# Altium schematic sheet MMB-2013-007a Micro Radio.SchDoc("MMB-2013-007a Micro Radio")
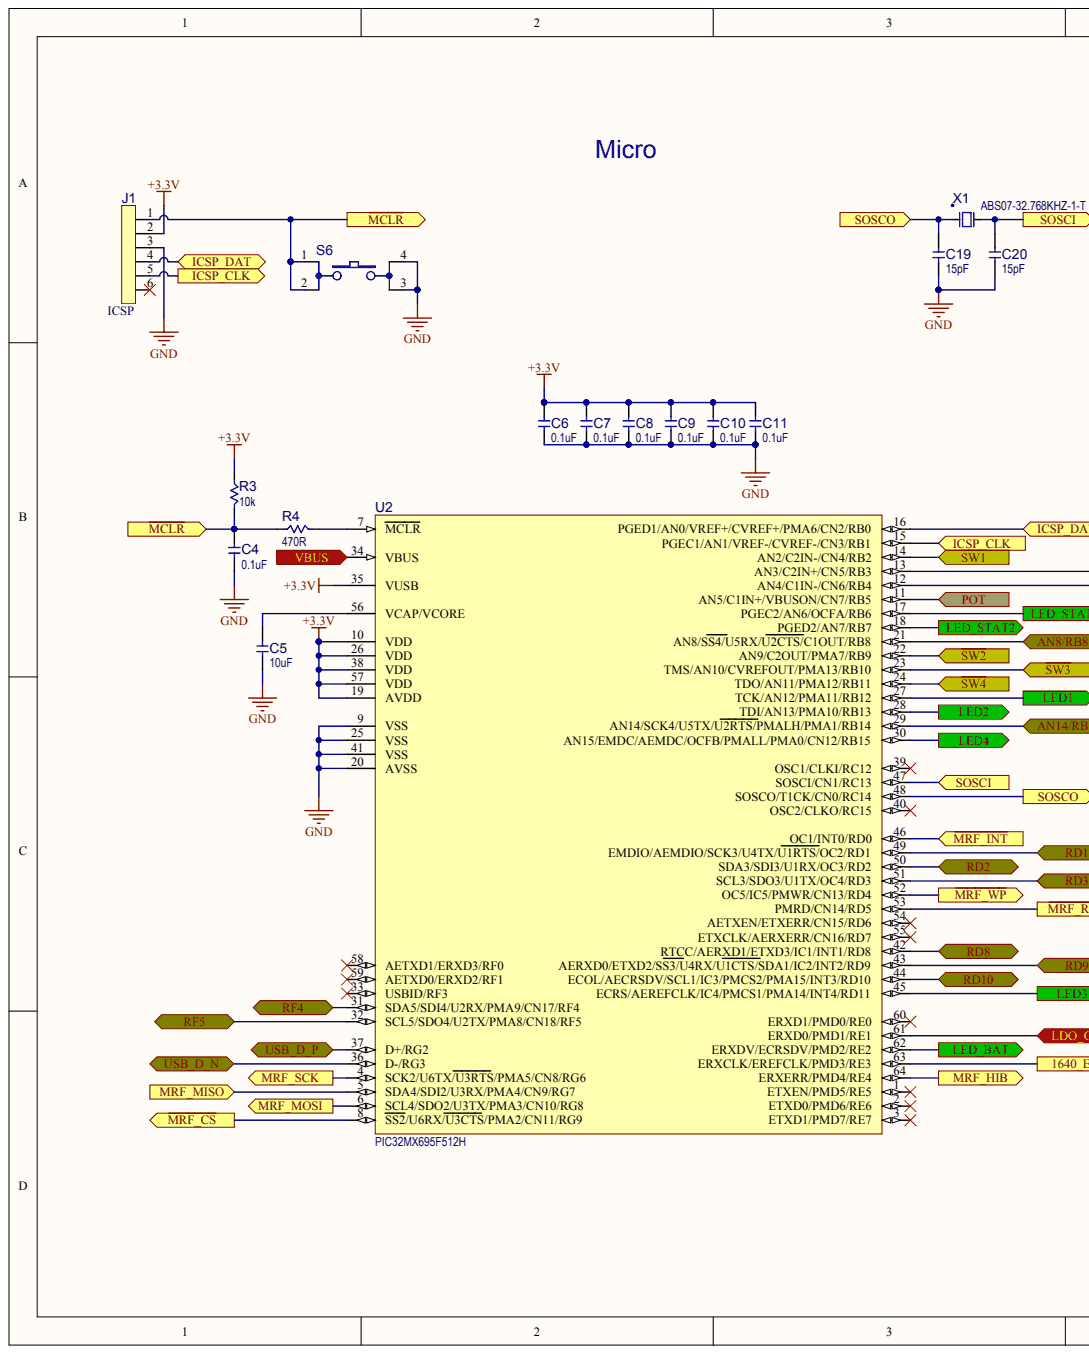
[diagram p0: MMB-2013-007a Micro Radio.SchDoc, left]
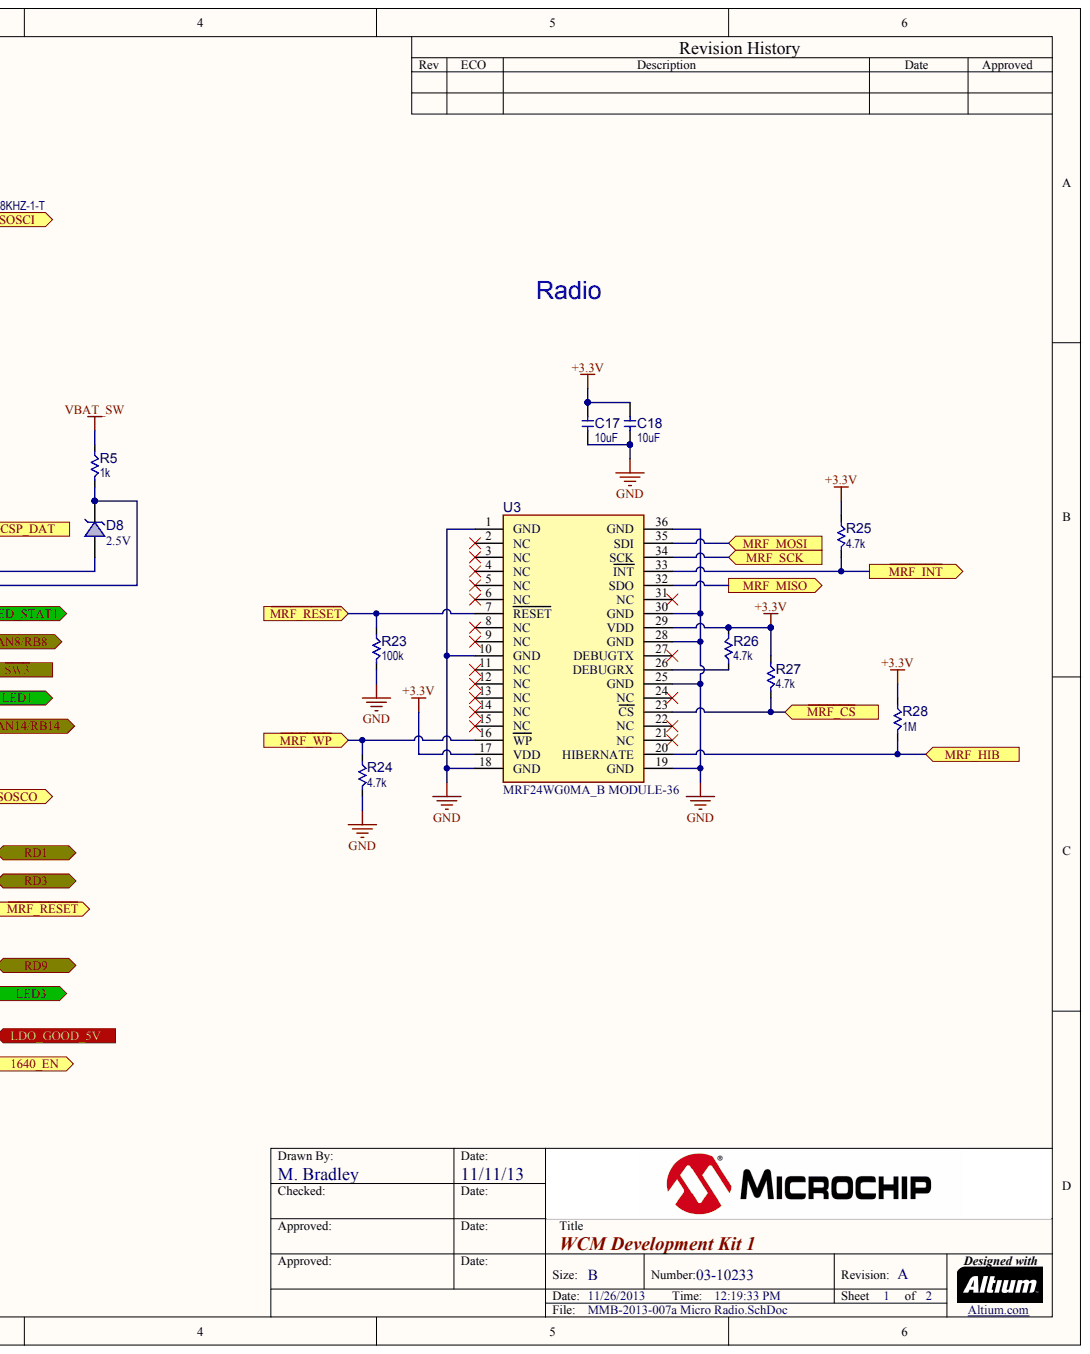
[diagram p1: MMB-2013-007a Micro Radio.SchDoc, right]

## Parts
C4  value 0.1uF  (hidden comment: Capacitor NP)
C5  value 10uF  (hidden comment: Capacitor NP)
C6  value 0.1uF  (hidden comment: Capacitor NP)
C7  value 0.1uF  (hidden comment: Capacitor NP)
C8  value 0.1uF  (hidden comment: Capacitor NP)
C9  value 0.1uF  (hidden comment: Capacitor NP)
C10  value 0.1uF  (hidden comment: Capacitor NP)
C11  value 0.1uF  (hidden comment: Capacitor NP)
C17  value 10uF  (hidden comment: Capacitor NP)
C18  value 10uF  (hidden comment: Capacitor NP)
C19  value 15pF  (hidden comment: Capacitor NP)
C20  value 15pF  (hidden comment: Capacitor NP)
D8  value 2.5V  (hidden comment: Diode Zener)
J1  ICSP
R3  value 10k  (hidden comment: Resistor)
R4  value 470R  (hidden comment: Resistor)
R5  value 1k  (hidden comment: Resistor)
R23  value 100k  (hidden comment: Resistor)
R24  value 4.7k  (hidden comment: Resistor)
R25  value 4.7k  (hidden comment: Resistor)
R26  value 4.7k  (hidden comment: Resistor)
R27  value 4.7k  (hidden comment: Resistor)
R28  value 1M  (hidden comment: Resistor)
S6  (no value)
U2  value PIC32MX695F512H  (hidden comment: PIC32MX6XX TQFP-64)
U3  MRF24WG0MA_B MODULE-36
X1  Manufacturer Part Number 1: ABS07-32.768KHZ-1-T  (hidden comment: Crystal 2 Pins)

## Ports
1640_EN, AN8/RB8, AN14/RB14, ICSP_CLK, ICSP_DAT, LDO_GOOD_5V, LED1, LED2, LED3, LED4, LED_BAT, LED_STAT1, LED_STAT2, MRF_HIB, MRF_MISO, MRF_MOSI, MRF_SCK, POT, RD1, RD2, RD3, RD8, RD9, RD10, RF4, RF5, SOSCI, SOSCO, USB_D_N, USB_D_P, VBUS, ~{MCLR}, ~{MRF_CS}, ~{MRF_INT}, ~{MRF_RESET}, ~{MRF_WP}, ~{SW1}, ~{SW2}, ~{SW3}, ~{SW4}

## Nets
+3.3V: C6.2 C7.2 C8.2 C9.2 C10.2 C11.2 C17.2 C18.2 J1.2 R3.2 R25.2 R26.1 R27.2 R28.2 U2.10(VDD) U2.19(AVDD) U2.26(VDD) U2.35(VUSB) U2.38(VDD) U2.57(VDD) U3.17(VDD) U3.29(VDD)
GND: C4.1 C5.1 C6.1 C7.1 C8.1 C9.1 C10.1 C11.1 C17.1 C18.1 C19.1 C20.1 J1.3 R23.1 R24.1 S6.3 S6.4 U2.9(VSS) U2.20(AVSS) U2.25(VSS) U2.41(VSS) U3.1(GND) U3.10(GND) U3.18(GND) U3.19(GND) U3.25(GND) U3.28(GND) U3.30(GND) U3.36(GND)
VBAT_SW: R5.2
1640_EN: U2.63(ERXCLK/EREFCLK/PMD3/RE3) ; port 1640_EN
AN8/RB8: U2.21(AN8/SS4/U5RX/U2CTS/C1OUT/RB8) ; port AN8/RB8
AN14/RB14: U2.29(AN14/SCK4/U5TX/U2RTS/PMALH/PMA1/RB14) ; port AN14/RB14
ICSP_CLK: J1.5 U2.15(PGEC1/AN1/VREF-/CVREF-/CN3/RB1) ; port ICSP_CLK
ICSP_DAT: J1.4 U2.16(PGED1/AN0/VREF+/CVREF+/PMA6/CN2/RB0) ; port ICSP_DAT
LDO_GOOD_5V: U2.61(ERXD0/PMD1/RE1) ; port LDO_GOOD_5V
LED1: U2.27(TCK/AN12/PMA11/RB12) ; port LED1
LED2: U2.28(TDI/AN13/PMA10/RB13) ; port LED2
LED3: U2.45(ECRS/AEREFCLK/IC4/PMCS1/PMA14/INT4/RD11) ; port LED3
LED4: U2.30(AN15/EMDC/AEMDC/OCFB/PMALL/PMA0/CN12/RB15) ; port LED4
LED_BAT: U2.62(ERXDV/ECRSDV/PMD2/RE2) ; port LED_BAT
LED_STAT1: U2.17(PGEC2/AN6/OCFA/RB6) ; port LED_STAT1
LED_STAT2: U2.18(PGED2/AN7/RB7) ; port LED_STAT2
~{MCLR}: C4.2 J1.1 R3.1 R4.2 S6.1 S6.2 ; port ~{MCLR}
~{MRF_CS}: R27.1 U2.8(SS2/U6RX/U3CTS/PMA2/CN11/RG9) U3.23(CS) ; port ~{MRF_CS}
~{MRF_INT}: R25.1 U2.46(OC1/INT0/RD0) U3.33(INT) ; port ~{MRF_INT}
~{MRF_RESET}: R23.2 U2.53(PMRD/CN14/RD5) U3.7(RESET) ; port ~{MRF_RESET}
~{MRF_WP}: R24.2 U2.52(OC5/IC5/PMWR/CN13/RD4) U3.16(WP) ; port ~{MRF_WP}
MRF_HIB: R28.1 U2.64(ERXERR/PMD4/RE4) U3.20(HIBERNATE) ; port MRF_HIB
MRF_MISO: U2.5(SDA4/SDI2/U3RX/PMA4/CN9/RG7) U3.32(SDO) ; port MRF_MISO
MRF_MOSI: U2.6(SCL4/SDO2/U3TX/PMA3/CN10/RG8) U3.35(SDI) ; port MRF_MOSI
MRF_SCK: U2.4(SCK2/U6TX/U3RTS/PMA5/CN8/RG6) U3.34(SCK) ; port MRF_SCK
POT: U2.11(AN5/C1IN+/VBUSON/CN7/RB5) ; port POT
RD1: U2.49(EMDIO/AEMDIO/SCK3/U4TX/U1RTS/OC2/RD1) ; port RD1
RD2: U2.50(SDA3/SDI3/U1RX/OC3/RD2) ; port RD2
RD3: U2.51(SCL3/SDO3/U1TX/OC4/RD3) ; port RD3
RD8: U2.42(RTCC/AERXD1/ETXD3/IC1/INT1/RD8) ; port RD8
RD9: U2.43(AERXD0/ETXD2/SS3/U4RX/U1CTS/SDA1/IC2/INT2/RD9) ; port RD9
RD10: U2.44(ECOL/AECRSDV/SCL1/IC3/PMCS2/PMA15/INT3/RD10) ; port RD10
RF4: U2.31(SDA5/SDI4/U2RX/PMA9/CN17/RF4) ; port RF4
RF5: U2.32(SCL5/SDO4/U2TX/PMA8/CN18/RF5) ; port RF5
~{SW1}: U2.14(AN2/C2IN-/CN4/RB2) ; port ~{SW1}
~{SW2}: U2.22(AN9/C2OUT/PMA7/RB9) ; port ~{SW2}
~{SW3}: U2.23(TMS/AN10/CVREFOUT/PMA13/RB10) ; port ~{SW3}
~{SW4}: U2.24(TDO/AN11/PMA12/RB11) ; port ~{SW4}
SOSCI: C20.2 U2.47(SOSCI/CN1/RC13) X1.2 ; port SOSCI
SOSCO: C19.2 U2.48(SOSCO/T1CK/CN0/RC14) X1.1 ; port SOSCO
USB_D_N: U2.36(D-/RG3) ; port USB_D_N
USB_D_P: U2.37(D+/RG2) ; port USB_D_P
VBUS: U2.34(VBUS) ; port VBUS
NetC5_2: C5.2 U2.56(VCAP/VCORE)
NetD8_1: D8.1 U2.13(AN3/C2IN+/CN5/RB3)
NetD8_3: D8.3 R5.1 U2.12(AN4/C1IN-/CN6/RB4)
NetR4_1: R4.1 U2.7(MCLR)
NetR26_2: R26.2 U3.26(DEBUGRX)

Not connected: J1 6; U2 1(ETXEN/PMD5/RE5) 2(ETXD0/PMD6/RE6) 3(ETXD1/PMD7/RE7) 33(USBID/RF3) 39(OSC1/CLKI/RC12) 40(OSC2/CLKO/RC15) 54(AETXEN/ETXERR/CN15/RD6) 55(ETXCLK/AERXERR/CN16/RD7) 58(AETXD1/ERXD3/RF0) 59(AETXD0/ERXD2/RF1) 60(ERXD1/PMD0/RE0); U3 2(NC) 3(NC) 4(NC) 5(NC) 6(NC) 8(NC) 9(NC) 11(NC) 12(NC) 13(NC) 14(NC) 15(NC) 21(NC) 22(NC) 24(NC) 27(DEBUGTX) 31(NC)

## Library data of the parts
C4 C6-C11 = (no symbol name): Manufacturer 1: TDK; Manufacturer Part Number 1: C1608X7R1C104K; MCHP-ID: CAP1166; Voltage: 16V; Description_: CAP CER 0.1uF 16V 10% X7R 0603; Tolerance: 10%; Temperature Coeffcient: X7R; Type: Ceramic; Owner: Bogdan P (M91398); Populated: Yes; Package: 0603; Revision: 0; Status: Production; ComponentLink1URL: https://chn-vm-apps.microchip.com/mchprepo/parts/Datasheets/general_B11.pdf; ComponentLink1Description: Datasheet; Operating Temperature: -55C to +125C; Category: Capacitor; Supplier 1: Digi-Key; Supplier Part Number 1: 445-1317-1-ND; Quantity Override: 1
C5 = (no symbol name): Manufacturer 1: Murata; Manufacturer Part Number 1: GRM21BR61C106KE15L; MCHP-ID: CAP0373; Voltage: 16V; Description_: CAP CER 10uF 16V 10% X5R SMD 0805; Tolerance: 10%; Temperature Coeffcient: X5R; Type: Ceramic; Populated: Yes; Package: 0805; Revision: 1; Status: Production; ComponentLink1URL: https://chn-vm-apps.microchip.com/mchprepo/parts/Datasheets/L0005E.pdf; ComponentLink1Description: Datasheet; Operating Temperature: +25C to +125C; Category: Capacitor; Manufacturer 2: Taiyo Yuden; Manufacturer Part Number 2: EMK212BJ106KG-T; Supplier 1: Digi-Key; Supplier Part Number 1: 490-3886-1-ND; Supplier 2: Digi-Key; Supplier Part Number 2: 587-1295-1-ND; Supplier 3: Newark; Supplier Part Number 3: 30K5459; Quantity Override: 1
C17 C18 = (no symbol name): Manufacturer 1: Taiyo Yuden; Manufacturer Part Number 1: JMK212BJ106MG-T; MCHP-ID: CAP0280; Voltage: 6.3V; Description_: CAP CER 10uF 6.3V 20% X5R SMD 0805; Tolerance: 20%; Temperature Coeffcient: X5R; Type: Ceramic; Populated: Yes; Package: 0805; Revision: 1; Status: Production; ComponentLink1URL: https://chn-vm-apps.microchip.com/mchprepo/parts/Datasheets/mlcc01_e.pdf; ComponentLink1Description: Datasheet; Operating Temperature: -55C to +85C; Category: Capacitor; Manufacturer 2: Murata; Manufacturer Part Number 2: GRM21BR60J106ME19L; Supplier 1: Digi-Key; Supplier Part Number 1: 587-1943-1-ND; Supplier 2: Digi-Key; Supplier Part Number 2: 490-1718-1-ND; Quantity Override: 1
C19 C20 = (no symbol name): Manufacturer 1: Panasonic; Manufacturer Part Number 1: ECJ-1VC1H150J; MCHP-ID: CAP0121; Voltage: 50V; Description_: CAP CER 15pF 50V 5% NP0 SMD 0603; Tolerance: 5%; Temperature Coeffcient: NP0; Type: Ceramic; Populated: Yes; Package: 0603; Revision: 1; Status: Production; ComponentLink1URL: https://chn-vm-apps.microchip.com/mchprepo/parts/Datasheets/MLCC_ordering_code.pdf; ComponentLink1Description: Datasheet; Operating Temperature: -55C to +125C; Category: Capacitor; Manufacturer 2: TDK; Manufacturer Part Number 2: C1608C0G1H150J; Manufacturer 3: Yageo; Manufacturer Part Number 3: CC0603JRNP09BN150; Supplier 1: Digi-Key; Supplier Part Number 1: PCC150ACVTR-ND; Supplier 2: Digi-Key; Supplier Part Number 2: 445-1271-1-ND; Supplier 3: Digi-Key; Supplier Part Number 3: 311-1060-1-ND; Quantity Override: 1
D8 = (no symbol name): Manufacturer 1: ON Semiconductor; Manufacturer Part Number 1: MMBZ5222BLT1G; MCHP-ID: DIODE1083; Description_: DIO ZNR MMBZ5222BLT1G 2.5V 225mW SMD SOT-23-3; Type: Zener Single; Forward Current: 10mA; Power: 225mW; Reverse Current: 100uA; Reverse Voltage: 1V; Populated: YES; Revision: B; Status: Design; Package: SOT-23-3; ComponentLink1URL: https://chn-vm-apps.microchip.com/mchprepo/parts/Datasheets/MMBZ5221BLT1-D.pdf; ComponentLink1Description: Datasheet; Operating Temperature: -65C to 150C; Category: Diode; Supplier 1: Digi-Key; Supplier Part Number 1: MMBZ5222BLT1GOSCT-ND; Quantity Override: 1
J1 = (no symbol name): Manufacturer 1: FCI; Manufacturer Part Number 1: 68016-106HLF; MCHP-ID: CON1017; Value: HDR-2.54 Male 1x6; Description_: CON HDR-2.54 Male 1x6 Gold 5.84MH TH R/A; Owner: Leah Whitaker; Populated: YES; Revision: 1; Status: Production; ComponentLink1URL: https://chn-vm-apps.microchip.com/mchprepo/parts/Datasheets/68015.pdf; ComponentLink1Description: Datasheet; Type: Header 2.54mm; Category: Connector; Supplier 1: Digi-Key; Supplier Part Number 1: 609-3313-ND; Quantity Override: 1
R3 = (no symbol name): Manufacturer 1: Panasonic; Manufacturer Part Number 1: ERJ-3GEYJ103V; MCHP-ID: RES0191; Tolerance: 5%; Description_: RES TKF 10k 5% 1/10W SMD 0603; Voltage: 75V; Temperature Coeffcient: 200ppm/C; Power: 1/10W; Populated: Yes; Package: 0603; Revision: 2; Status: Production; ComponentLink1URL: https://chn-vm-apps.microchip.com/mchprepo/parts/Datasheets/AOA0000CE1.pdf; ComponentLink1Description: Datasheet; Operating Temperature: -55C to +155C; Category: Resistor; Type: RES SMD; Composition: TKF; Manufacturer 2: NIC Components; Manufacturer Part Number 2: NRC06J103TRF; Manufacturer 3: Yageo; Manufacturer Part Number 3: RC0603JR-0710KL; Supplier 1: Digi-Key; Supplier Part Number 1: P10KGCT-ND; Supplier 2: Digi-Key; Supplier Part Number 2: P10KGTR-ND; Supplier 3: NIC Components; Supplier Part Number 3: NRC06J103TRF; Supplier 4: Digi-Key; Supplier Part Number 4: 311-10KGRTR-ND; Quantity Override: 1
R4 = (no symbol name): Manufacturer 1: NIC Components; Manufacturer Part Number 1: NRC06J471TRF; MCHP-ID: RSMT0098; Tolerance: 5%; Description_: RES TKF 470R 5% 1/10W SMD 0603; Temperature Coeffcient: 200ppm/C; Power: 1/10W; Populated: Yes; Package: 0603; Revision: 1; Status: Production; ComponentLink1URL: https://chn-vm-apps.microchip.com/mchprepo/parts/Datasheets/nrc.pdf; ComponentLink1Description: Datasheet; Operating Temperature: -55C to +155C; Category: Resistor; Type: RES SMD; Composition: TKF; Manufacturer 2: Panasonic; Manufacturer Part Number 2: ERJ-3GEYJ471V; Manufacturer 3: Stackpole Electronics Inc; Manufacturer Part Number 3: RMCF0603JT470R; Supplier 1: NIC Components; Supplier Part Number 1: NRC06J471TRF; Supplier 2: Digi-Key; Supplier Part Number 2: P470GTR-ND; Supplier 3: Digi-Key; Supplier Part Number 3: RMCF0603JT470RCT-ND; Quantity Override: 1
R5 = (no symbol name): Manufacturer 1: Panasonic; Manufacturer Part Number 1: ERJ-3GEYJ102V; MCHP-ID: RSMT0047; Tolerance: 5%; Description_: RES TKF 1k 5% 1/10W SMD 0603; Voltage: 75V; Temperature Coeffcient: 200ppm/C; Power: 1/10W; Populated: Yes; Package: 0603; Revision: 1; Status: Production; ComponentLink1URL: https://chn-vm-apps.microchip.com/mchprepo/parts/Datasheets/AOA0000CE1.pdf; ComponentLink1Description: Datasheet; Operating Temperature: -55C to +155C; Category: Resistor; Type: RES SMD; Composition: TKF; Manufacturer 2: KOA Speer; Manufacturer Part Number 2: RK73B1JTTD102J; Manufacturer 3: Panasonic; Manufacturer Part Number 3: ERJ-3GSYJ102V; Manufacturer 4: ROHM; Manufacturer Part Number 4: MCR03EZHJ1K; Manufacturer 5: ROHM; Manufacturer Part Number 5: MCR03FZHJ1001; Manufacturer 6: Stackpole Electronics Inc; Manufacturer Part Number 6: RMCF 1/16 1K 5% R; Manufacturer 7: Yageo; Manufacturer Part Number 7: RC0603JR-071KL; Supplier 1: Digi-Key; Supplier Part Number 1: P1.0KGCT-ND; Supplier 2: Digi-Key; Supplier Part Number 2: P1.0KGTR-ND; Supplier 3: KOA Speer; Supplier Part Number 3: RK73B1JTTD102J; Supplier 4: ROHM; Supplier Part Number 4: MCR03EZHJ1K; Supplier 5: ROHM; Supplier Part Number 5: MCR03FZHJ1001; Supplier 6: Stackpole Electronics Inc; Supplier Part Number 6: RMCF 1/16 1K 5% R; Supplier 7: Digi-Key; Supplier Part Number 7: 311-1.0KGRTR-ND; Quantity Override: 1
R23 = (no symbol name): Manufacturer 1: Panasonic; Manufacturer Part Number 1: ERJ-3GEYJ104V; MCHP-ID: RSMT0026; Tolerance: 5%; Description_: RES TKF 100k 5% 1/10W SMD 0603; Voltage: 75V; Temperature Coeffcient: 200ppm/C; Power: 1/10W; Populated: Yes; Package: 0603; Revision: 2; Status: Production; ComponentLink1URL: https://chn-vm-apps.microchip.com/mchprepo/parts/Datasheets/AOA0000CE1.pdf; ComponentLink1Description: Datasheet; Operating Temperature: -55C to +155C; Category: Resistor; Type: RES SMD; Composition: TKF; Manufacturer 2: KOA Speer; Manufacturer Part Number 2: RK73B1JTTD104J; Manufacturer 3: Panasonic; Manufacturer Part Number 3: ERJ-3GSYJ104V; Manufacturer 4: ROHM; Manufacturer Part Number 4: MCR03EZPJ104; Manufacturer 5: Stackpole Electronics Inc; Manufacturer Part Number 5: RMCF 1/16 100K 5% R; Supplier 1: Digi-Key; Supplier Part Number 1: P100KGTR-ND; Supplier 2: KOA Speer; Supplier Part Number 2: RK73B1JTTD104J; Supplier 3: Digi-Key; Supplier Part Number 3: RHM100KGTR-ND; Supplier 4: Stackpole Electronics Inc; Supplier Part Number 4: RMCF 1/16 100K 5% R; Quantity Override: 1
R24-R27 = (no symbol name): Manufacturer 1: Yageo; Manufacturer Part Number 1: RC0603JR-074K7L; MCHP-ID: RSMT0092; Tolerance: 5%; Description_: RES TKF 4.7k 5% 1/10W SMD 0603; Voltage: 50V; Temperature Coeffcient: 100ppm/C; Power: 1/10W; Populated: Yes; Package: 0603; Revision: 1; Status: Production; ComponentLink1URL: https://chn-vm-apps.microchip.com/mchprepo/parts/Datasheets/PYu-RC0603_51_RoHS_L_4.pdf; ComponentLink1Description: Datasheet; Operating Temperature: -55C to +155C; Category: Resistor; Type: RES SMD; Composition: TKF; Manufacturer 2: Yageo; Manufacturer Part Number 2: 9C06031A4701JLHFT; Manufacturer 3: KOA Speer; Manufacturer Part Number 3: RK73B1JTTD472J; Manufacturer 4: Panasonic; Manufacturer Part Number 4: ERJ-3GEYJ472V; Manufacturer 5: ROHM; Manufacturer Part Number 5: MCR03EZHJ4.7K; Manufacturer 6: Stackpole Electronics Inc; Manufacturer Part Number 6: RMCF 1/16 4.7K 5% R; Manufacturer 7: Yageo; Manufacturer Part Number 7: 9C06031A4701JLPFT; Manufacturer 8: NIC Components; Manufacturer Part Number 8: NRC06J472TRF; Supplier 1: Digi-Key; Supplier Part Number 1: 311-4.7KGRTR-ND; Supplier 2: Digi-Key; Supplier Part Number 2: 311-4.7KGTR-ND; Supplier 3: KOA Speer; Supplier Part Number 3: RK73B1JTTD472J; Supplier 4: Digi-Key; Supplier Part Number 4: P4.7KGTR-ND; Supplier 5: Digi-Key; Supplier Part Number 5: P4.7KGCT-ND; Supplier 6: ROHM; Supplier Part Number 6: MCR03EZHJ4.7K; Supplier 7: Stackpole Electronics Inc; Supplier Part Number 7: RMCF 1/16 4.7K 5% R; Supplier 8: Yageo; Supplier Part Number 8: 9C06031A4701JLPFT; Supplier 9: Avnet; Supplier Part Number 9: NRC06J472TRF; Quantity Override: 1
R28 = (no symbol name): Manufacturer 1: Yageo; Manufacturer Part Number 1: 9C06031A1004JLHFT; MCHP-ID: RSMT0187; Tolerance: 5%; Description_: RES TKF 1M 5% 1/10W SMD 0603; Voltage: 50V; Temperature Coeffcient: 100ppm/C; Power: 1/10W; Populated: Yes; Package: 0603; Revision: 1; Status: Production; ComponentLink1URL: https://chn-vm-apps.microchip.com/mchprepo/parts/Datasheets/PYu-RC0603_51_RoHS_L_4.pdf; ComponentLink1Description: Datasheet; Operating Temperature: -55C to +155C; Category: Resistor; Type: RES SMD; Composition: TKF; Manufacturer 2: KOA Speer; Manufacturer Part Number 2: RK73B1JTTD105J; Manufacturer 3: Panasonic; Manufacturer Part Number 3: ERJ-3GEYJ105V; Manufacturer 4: Stackpole Electronics Inc; Manufacturer Part Number 4: RMCF1/161M5%R; Manufacturer 5: Yageo; Manufacturer Part Number 5: RC0603JR-071ML; Manufacturer 6: Yageo; Manufacturer Part Number 6: 9C06031A1004JLPFT; Manufacturer 7: Stackpole Electronics Inc; Manufacturer Part Number 7: RMCF0603JT1M00; Supplier 1: Yageo; Supplier Part Number 1: 9C06031A1004JLHFT; Supplier 2: KOA Speer; Supplier Part Number 2: RK73B1JTTD105J; Supplier 3: Digi-Key; Supplier Part Number 3: P1.0MGTR-ND; Supplier 4: Stackpole Electronics Inc; Supplier Part Number 4: RMCF1/161M5%R; Supplier 5: Yageo; Supplier Part Number 5: RC0603JR-071ML; Supplier 6: Yageo; Supplier Part Number 6: 9C06031A1004JLPFT; Supplier 7: Digi-Key; Supplier Part Number 7: RMCF0603JT1M00CT-ND; Quantity Override: 1
S6 = (no symbol name): Manufacturer 1: E-Switch; Manufacturer Part Number 1: TL3301AF160QG; MCHP-ID: SWITCH0095; Value: SPST; Description_: SWITCH SPST 12V 50mA TL3301AF160QG SMD; Voltage: 12V; Current: 50mA; Populated: Yes; Revision: 1; Status: Production; ComponentLink1URL: https://chn-vm-apps.microchip.com/mchprepo/parts/Datasheets/TL3301.pdf; ComponentLink1Description: Datasheet; Operating Temperature: -20C to +70C; Category: Switch; Supplier 1: Digi-Key; Supplier Part Number 1: EG2526CT-ND; Quantity Override: 1
U2 = (no symbol name): Manufacturer 1: Microchip; Manufacturer Part Number 1: PIC32MX695F512HT-80I/PT; MCHP-ID: MIC5525; Description_: MCHP MCU 32-BIT 80MHz 512kB 128kB PIC32MX695F512H-80I/PT TQFP-64; MAPS Description: 32 Bit MCU, 512KB Flash, 128KB RAM, 80 MHz, 64 Pin, USB, ENET; MPC: TDAA1TV2XDA8; LeadContent: Pb-FREE; TempRange: -40C to +85C; Stage: REL; PackageWidth: 10x10x1mm; PackingMedia: T/R; ForceMinOrderQtyMult: True; MinOrderQty: 1200; LeadCount: 64; Program Memory KBytes: 512; Program Memory KWords: 128; Ram: 131072; EEPROM: 0; IOPins: 53; MaxSpeed: 80; OscillatorMHz: 8 MHz, 32 kHz; Comparators: 2; ADC Channels: 16; ADCBit: 10; USART: 6; SPI: 3; I2C: 4; USBChannels: 1; Input Capture: 5; Populated: Yes; Type: 32-Bit; Status: Production; Revision: 0; Category: MCHP-Microcontroller; ComponentLink1URL: http://www.microchip.com/stellent/idcplg?IdcService=SS_GET_PAGE&nodeId=1335&dDocName=en545654; ComponentLink1Description: Datasheet; Manufacturer 2: Microchip; Manufacturer Part Number 2: PIC32MX695F512H-80I/PT; Supplier 1: Microchip; Supplier Part Number 1: PIC32MX695F512HT-80I/PT; Supplier 2: Microchip; Supplier Part Number 2: PIC32MX695F512H-80I/PT; IntroductionDate: 2009-11-20; PackageType: TQFP; Family: 32-Bit MCU; PWM Channels: 5; CapTouchCH: 16; DigitalTimerQty_8bit: 0; DigitalTimerQty_16bit: 5; DigitalTimerQty_32bit: 2; Quantity Override: 1
U3 = (no symbol name): Manufacturer 1: Microchip Technology; Manufacturer Part Number 1: MRF24WG0MA-I/RM; MCHP-ID: MIC6035; Description_: MCHP MRF 2.4GHz 18dBm MRF24WG0MA-I/RM MODULE-36; MAPS Description: PCB ANTENNA MODULE; Owner: Duane Burt; tblDeviceFamilyID: 3546; CRC: False; EncryptionType: AES128; FrequencyRange: 2.405-2.48; MAC: Yes; MACfeatures: CSMA-CA; PowerRX: 95; PowerTX: 240; Sensitivity: -95; Type: MRF; Status: Design; Revision: C; Value: MRF24WG0MA; Category: MCHP-Wireless; ComponentLink1URL: http://www.microchip.com/stellent/idcplg?IdcService=SS_GET_PAGE&nodeId=1335&dDocName=en559196; ComponentLink1Description: Datasheet; Supplier 1: Digi-Key; Supplier Part Number 1: MRF24WG0MA-I/RM-ND; RSSI: Yes; Sleep: Yes; SelectableLNAGain: False; Populated: YES; Quantity Override: 1
X1 = (no symbol name): Manufacturer 1: Abracon; MCHP-ID: OSC1114; Value: 32.768kHz; Description_: CRYSTAL 32.768kHz 12.5pF SMD ABS07; Owner: Adrian M (M91404); Tolerance: 10ppm; Load Capacitance: 12.5pF; Populated: Yes; Revision: 0; Status: Production; ComponentLink1URL: https://chn-vm-apps.microchip.com/mchprepo/parts/Datasheets/ABS07.pdf; ComponentLink1Description: Datasheet; Operating Temperature: -40C to +85C; Package: SMD_ABS07; Type: Crystal; Category: Crystal/Oscillator; Supplier 1: Digi-Key; Supplier Part Number 1: 535-9808-1-ND; Quantity Override: 1
